# Altium schematic sheet CurrentSensorR2.SchDoc
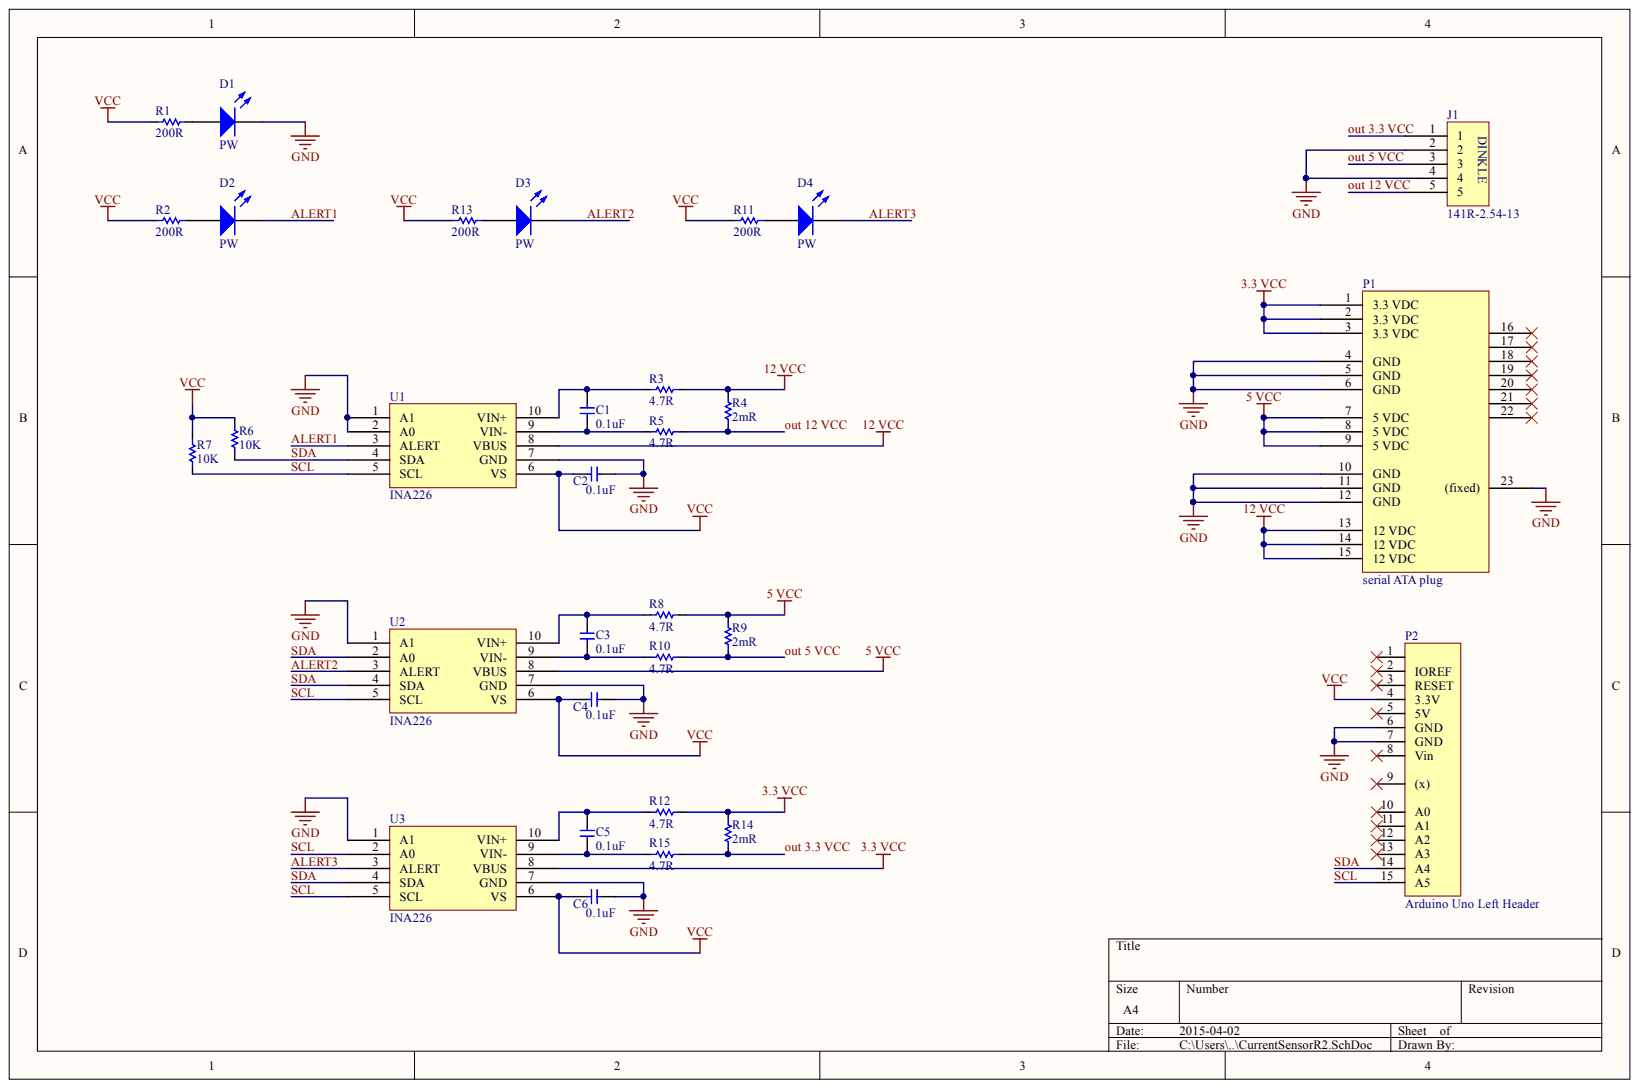
[diagram p0: CurrentSensorR2.SchDoc]

## Parts
C1  0.1uF  [C1608]
C2  0.1uF  [C1608]
C3  0.1uF  [C1608]
C4  0.1uF  [C1608]
C5  0.1uF  [C1608]
C6  0.1uF  [C1608]
D1  PW  [LED-0603]
D2  PW  [LED-0603]
D3  PW  [LED-0603]
D4  PW  [LED-0603]
J1  141R-2.54-13  [141R-5p]
P1  serial ATA plug  [87703-0001]
P2  Arduino Uno Left Header  [HDR1X15 for Arduino]
R1  200R  [R1608]
R2  200R  [R1608]
R3  4.7R  [R1608]
R4  2mR  [R-Shunt]
R5  4.7R  [R1608]
R6  10K  [R1608]
R7  10K  [R1608]
R8  4.7R  [R1608]
R9  2mR  [R-Shunt]
R10  4.7R  [R1608]
R11  200R  [R1608]
R12  4.7R  [R1608]
R13  200R  [R1608]
R14  2mR  [R-Shunt]
R15  4.7R  [R1608]
U1  INA226  [DGS10-M]
U2  INA226  [DGS10-M]
U3  INA226  [DGS10-M]

## Nets
3.3 VCC: P1.1(3.3_VDC) P1.2(3.3_VDC) P1.3(3.3_VDC) R12.2 R14.2 U3.8(VBUS)
5 VCC: P1.7(5_VDC) P1.8(5_VDC) P1.9(5_VDC) R8.2 R9.2 U2.8(VBUS)
12 VCC: P1.13(12_VDC) P1.14(12_VDC) P1.15(12_VDC) R3.2 R4.2 U1.8(VBUS)
GND: C2.1 C4.1 C6.1 D1.K J1.2 J1.4 P1.4(GND) P1.5(GND) P1.6(GND) P1.10(GND) P1.11(GND) P1.12(GND) P1.23((fixed)) P2.6(GND) P2.7(GND) U1.1(A1) U1.2(A0) U1.7(GND) U2.1(A1) U2.7(GND) U3.1(A1) U3.7(GND)
VCC: C2.2 C4.2 C6.2 P2.4(3.3V) R1.1 R2.1 R6.2 R7.2 R11.1 R13.1 U1.6(VS) U2.6(VS) U3.6(VS)
ALERT1: D2.K U1.3(ALERT)
ALERT2: D3.K U2.3(ALERT)
ALERT3: D4.K U3.3(ALERT)
OUT 3.3 VCC: J1.1 R14.1 R15.2
OUT 5 VCC: J1.3 R9.1 R10.2
OUT 12 VCC: J1.5 R4.1 R5.2
SCL: P2.15(A5) R7.1 U1.5(SCL) U2.5(SCL) U3.2(A0) U3.5(SCL)
SDA: P2.14(A4) R6.1 U1.4(SDA) U2.2(A0) U2.4(SDA) U3.4(SDA)
NetC1_1: C1.1 R5.1 U1.9(VIN-)
NetC1_2: C1.2 R3.1 U1.10(VIN+)
NetC3_1: C3.1 R10.1 U2.9(VIN-)
NetC3_2: C3.2 R8.1 U2.10(VIN+)
NetC5_1: C5.1 R15.1 U3.9(VIN-)
NetC5_2: C5.2 R12.1 U3.10(VIN+)
NetD1_A: D1.A R1.2
NetD2_A: D2.A R2.2
NetD3_A: D3.A R13.2
NetD4_A: D4.A R11.2

Not connected: P1 16 17 18 19 20 21 22; P2 1 2(IOREF) 3(RESET) 5(5V) 8(Vin) 9((x)) 10(A0) 11(A1) 12(A2) 13(A3)

## Library data of the parts
C1-C6 = Cap: Capacitor; Value: 100pF; Component Kind: Standard; LatestRevisionDate: 17-Jul-2002; LatestRevisionNote: Re-released for DXP Platform.; PackageDescription: Radial Cap, Thru-Hole; 2 Leads; 0.3 in Pin Spacing; PackageReference: RAD-0.3; Published: 8-Jun-2000; Library Name: jae.SchLib; Publisher: Altium Limited
D1-D4 = LED: Component Kind: Standard; Description: LED; LatestRevisionDate: 08-Jul-2005; LatestRevisionNote: Mech Layer 1 removed.; PackageDescription: LED; 2 Leads; PackageReference: LED-0; Published: 8-Jun-2000; Library Name: jae.SchLib; Publisher: Altium Limited
P1 = serial ATA plug: Vendor: Texas Instruments; Component Kind: Standard; Description: serial ATA plug; Value: Value; Library Name: jae.SchLib
P2 = Arduino Uno Left Header: Arduino Uno, L, Header, 15-Pin; Component Kind: Standard; LatestRevisionDate: 17-Jul-2002; LatestRevisionNote: Re-released for DXP Platform.; Library Name: jae.SchLib; Publisher: Altium Limited
R1-R15 = Res: Resistor; Value: 1K; Component Kind: Standard; LatestRevisionDate: 17-Jul-2002; LatestRevisionNote: Re-released for DXP Platform.; PackageDescription: Axial Device, Thru-Hole; 2 Leads; 0.3 in Pin Spacing; PackageReference: AXIAL-0.3; Published: 8-Jun-2000; Library Name: jae.SchLib; Publisher: Altium Limited
U1-U3 = INA226_DGS_10: Imported; Manufacturer: Texas Instruments; FAMILY_NAME: CURRENT SHUNT MONITOR; INDUSTRY_STD_PKG_TYPE: MSOP; PACKAGE_DESIGNATOR: DGS; PIN_COUNT: 10; Component Kind: Standard; Datasheet URL: http://www.ti.com/lit/gpn/INA226; GENERIC_PART_NUMBER: INA226; Manufacturer_Part_Number: ina226_dgs_10; RefDes: RefDes; Type: DEV; Value: Value; Vendor: Texas Instruments; Library Name: jae.SchLib
J1 = 141R-2.54-13: Component Kind: Standard; Library Name: jae.SchLib
